# Altium schematic sheet FFCAD_GEN2.SchDoc(FFCAD_GEN2)
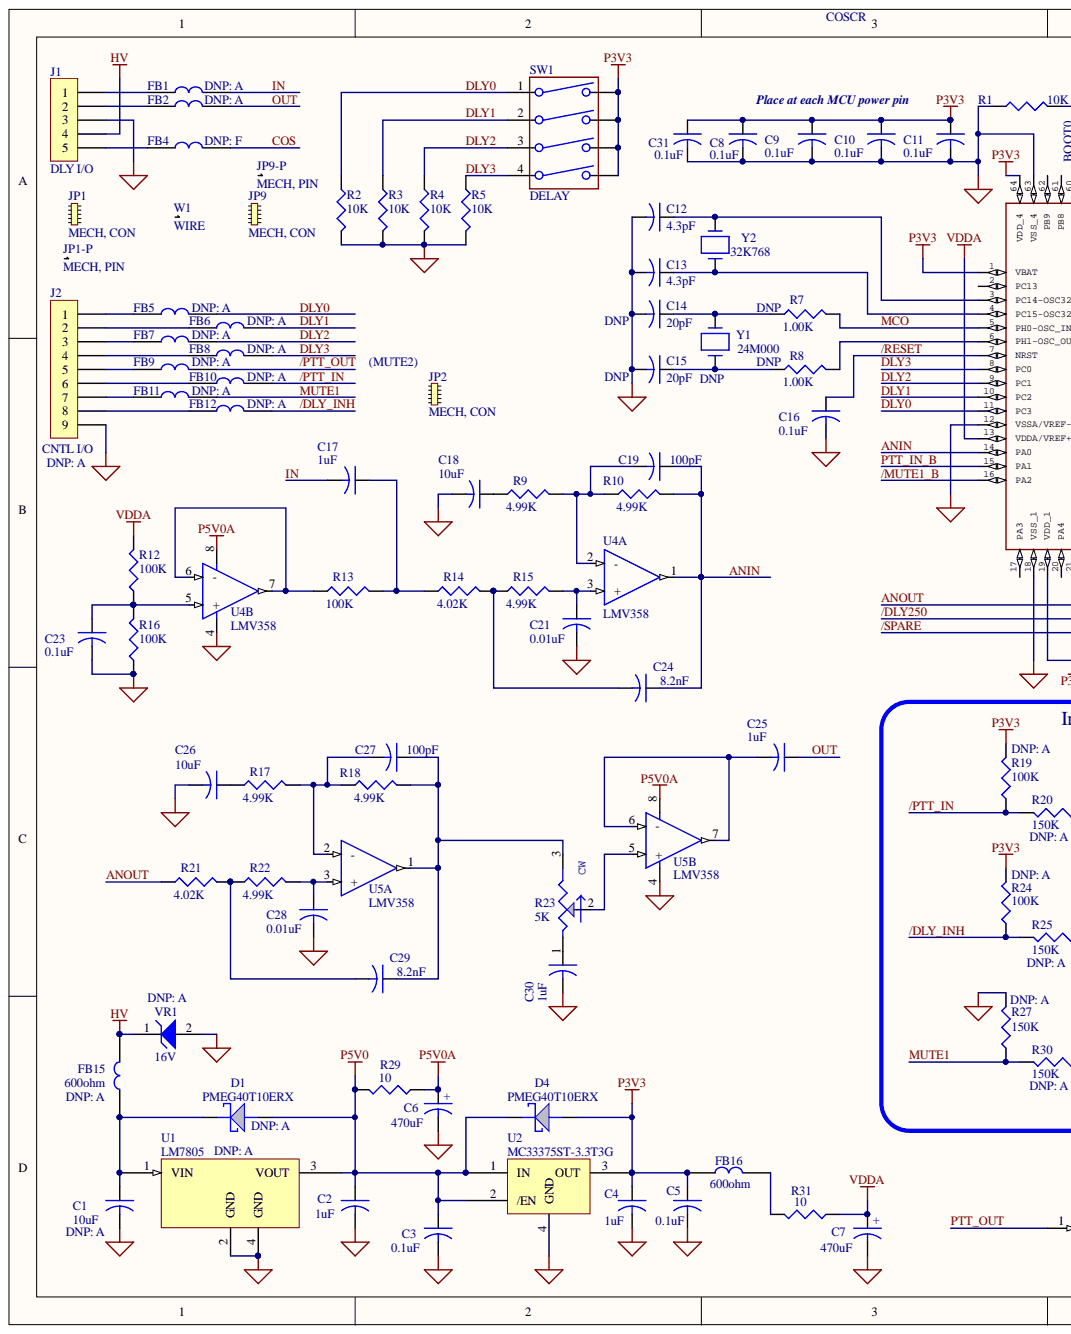
[diagram p0: FFCAD_GEN2.SchDoc, left]
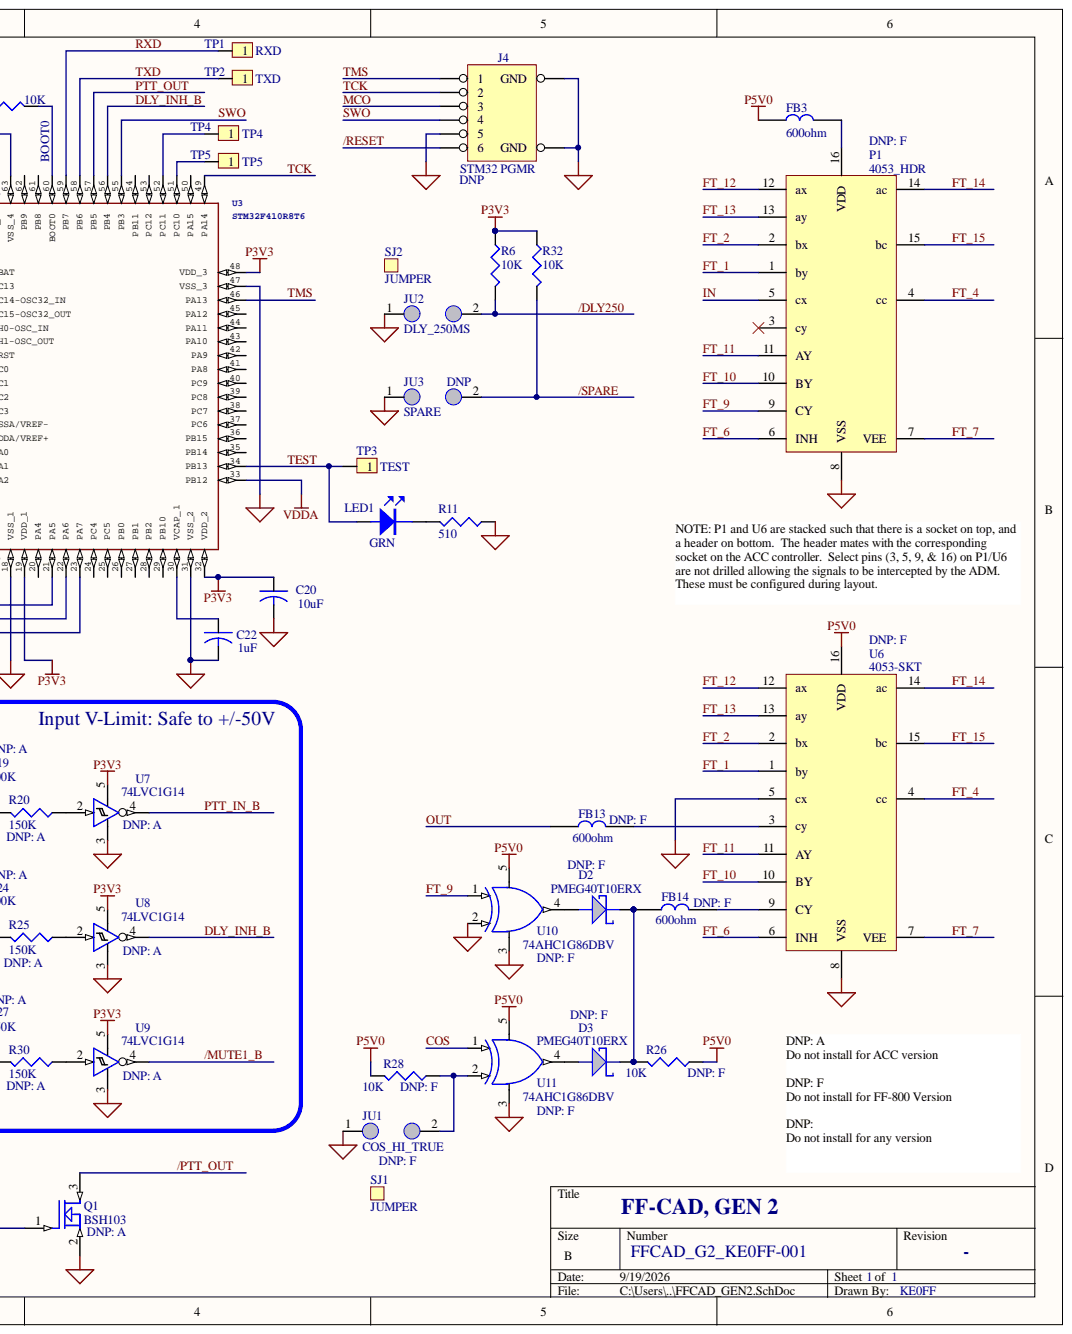
[diagram p1: FFCAD_GEN2.SchDoc, right]

## Parts
C1  10uF  DNP: DNP: A  [1206]
C2  1uF  [0805]
C3  0.1uF  [0402]
C4  1uF  [0805]
C5  0.1uF  [0402]
C6  470uF  [2917]
C7  470uF  [2917]
C8  0.1uF  [0402]
C9  0.1uF  [0402]
C10  0.1uF  [0402]
C11  0.1uF  [0402]
C12  4.3pF  [0402]
C13  4.3pF  [0402]
C14  20pF  [0402]
C15  20pF  [0402]
C16  0.1uF  [0402]
C17  1uF  [0805]
C18  10uF  [1206]
C19  100pF  [0402]
C20  10uF  [1206]
C21  0.01uF  [0805]
C22  1uF  [0805]
C23  0.1uF  [0402]
C24  8.2nF  [0805]
C25  1uF  [0805]
C26  10uF  [1206]
C27  100pF  [0402]
C28  0.01uF  [0805]
C29  8.2nF  [0805]
C30  1uF  [0805]
C31  0.1uF  [0402]
D1  PMEG40T10ERX  DNP: DNP: A  [SOD123]
D2  PMEG40T10ERX  DNP: DNP: F  [SOD123]
D3  PMEG40T10ERX  DNP: DNP: F  [SOD123]
D4  PMEG40T10ERX  [SOD123]
FB1  DNP: DNP: A  [0603]  (hidden comment: 600ohm)
FB2  DNP: DNP: A  [0603]  (hidden comment: 600ohm)
FB3  600ohm  [0603]
FB4  DNP: DNP: F  [0603]  (hidden comment: 600ohm)
FB5  DNP: DNP: A  [0603]  (hidden comment: 600ohm)
FB6  DNP: DNP: A  [0603]  (hidden comment: 600ohm)
FB7  DNP: DNP: A  [0603]  (hidden comment: 600ohm)
FB8  DNP: DNP: A  [0603]  (hidden comment: 600ohm)
FB9  DNP: DNP: A  [0603]  (hidden comment: 600ohm)
FB10  DNP: DNP: A  [0603]  (hidden comment: 600ohm)
FB11  DNP: DNP: A  [0603]  (hidden comment: 600ohm)
FB12  DNP: DNP: A  [0603]  (hidden comment: 600ohm)
FB13  600ohm  DNP: DNP: F  [0603]
FB14  600ohm  DNP: DNP: F  [0603]
FB15  600ohm  DNP: DNP: A  [0603]
FB16  600ohm  [0603]
J1  DLY I/O  [MOLEX-05]
J2  CNTL I/O  DNP: DNP: A  [MOLEX-09]
J4  STM32 PGMR  [CON_1.25MM_6P]
JP1  MECH, CON
JP1-P  MECH, PIN  (mechanical)
JP2  MECH, CON
JP9  MECH, CON
JP9-P  MECH, PIN  (mechanical)
JU1  COS_HI_TRUE  [BERG_1X2]
JU2  DLY_250MS  [BERG_1X2]
JU3  SPARE  [BERG_1X2]
LED1  GRN  [0603-LED]
P1  4053_HDR  DNP: DNP: F  [DIP16]
Q1  BSH103  [SOT23-A]
R1  10K  [0402]
R2  10K  [0402]
R3  10K  [0402]
R4  10K  [0402]
R5  10K  [0402]
R6  10K  [0402]
R7  1.00K  [0402]
R8  1.00K  [0402]
R9  4.99K  [0402]
R10  4.99K  [0402]
R11  510  [0402]
R12  100K  [0402]
R13  100K  [0402]
R14  4.02K  [0402]
R15  4.99K  [0402]
R16  100K  [0402]
R17  4.99K  [0402]
R18  4.99K  [0402]
R19  100K  DNP: DNP: A  [0402]
R20  150K  DNP: DNP: A  [0402]
R21  4.02K  [0402]
R22  4.99K  [0402]
R23  5K  [POT_3266W]
R24  100K  DNP: DNP: A  [0402]
R25  150K  DNP: DNP: A  [0402]
R26  10K  DNP: DNP: F  [0402]
R27  150K  DNP: DNP: A  [0402]
R28  10K  DNP: DNP: F  [0402]
R29  10  [0402]
R30  150K  DNP: DNP: A  [0402]
R31  10  [0402]
R32  10K  [0402]
SJ1  JUMPER  (mechanical)
SJ2  JUMPER  (mechanical)
SW1  DELAY  [DIP8]
TP1  RXD  [TP-SMD-20x50-SQ]  (no BOM)
TP2  TXD  [TP-SMD-20x50-SQ]  (no BOM)
TP3  TEST  [TP-SMD-20x50-SQ]  (no BOM)
TP4  TP4  [TP-SMD-20x50-SQ]  (no BOM)
TP5  TP5  [TP-SMD-20x50-SQ]  (no BOM)
U1  LM7805  DNP: DNP: A  [SOT223]
U2  MC33375ST-3.3T3G  [SOT223]
U3  STM32F410R8T6  [QFP50P1200X1200X160-64N]
U4  LMV358  [TSSOP-8]  (parts A,B)
U5  LMV358  [TSSOP-8]  (parts A,B)
U6  4053-SKT  DNP: DNP: F  [DIP16]
U7  74LVC1G14  DNP: DNP: A  [SOT-23-5]
U8  74LVC1G14  DNP: DNP: A  [SOT-23-5]
U9  74LVC1G14  DNP: DNP: A  [SOT-23-5]
U10  74AHC1G86DBV  DNP: DNP: F  [SOT-23-5]
U11  74AHC1G86DBV  DNP: DNP: F  [SOT-23-5]
VR1  16V  DNP: DNP: A  [SMB_POL]
W1  WIRE  (mechanical)
Y1  24M000  [XTAL_SMD]
Y2  32K768  [XTAL_AB25TRB]

## Nets
3: Y2.3
GND: C1.2 C2.2 C3.2 C4.2 C5.2 C6.2 C7.2 C8.2 C9.2 C10.2 C11.2 C12.2 C13.2 C14.2 C15.2 C16.2 C18.2 C20.2 C21.2 C22.2 C23.2 C26.2 C28.2 C30.2 C31.2 J1.3 J2.9 J4.5 J4.7(GND) J4.8(GND) JU1.1 JU2.1 JU3.1 P1.8(VSS) Q1.2 R1.1 R2.2 R3.2 R4.2 R5.2 R11.1 R16.1 R27.2 U1.2(GND) U1.4(GND) U2.4(GND) U3.12(VSSA/VREF-) U3.18(VSS_1) U3.31(VSS_2) U3.47(VSS_3) U3.63(VSS_4) U4.4(+) U5.4(+) U6.5(cx) U6.8(VSS) U7.3 U8.3 U9.3 U10.2 U10.3 U11.3 VR1.2
HV: FB15.2 J1.4 VR1.1
P3V3: C4.1 C5.1 C8.1 C9.1 C10.1 C11.1 C20.1 C31.1 D4.2 FB16.1 R6.1 R19.2 R24.2 R32.1 SW1.5 SW1.6 SW1.7 SW1.8 U2.3(OUT) U3.1(VBAT) U3.19(VDD_1) U3.32(VDD_2) U3.48(VDD_3) U3.64(VDD_4) U7.5 U8.5 U9.5
P5V0: C2.1 C3.1 D1.2 D4.1 FB3.1 R26.1 R28.2 R29.2 U1.3(VOUT) U2.1(IN) U2.2(/EN) U6.16(VDD) U10.5 U11.5
P5V0A: C6.1 R29.1 U4.8(-) U5.8
VDDA: C7.1 R12.2 R31.1 U3.13(VDDA/VREF+) U3.33(PB12)
/DLY250: JU2.2 R6.2 U3.22(PA6)
/DLY_INH: FB12.2 R24.1 R25.2
/MUTE1_B: U3.16(PA2) U9.4
/PTT_IN: FB10.2 R19.1 R20.2
/PTT_OUT: FB9.2 Q1.3
/RESET: C16.1 J4.6 U3.7(NRST)
/SPARE: JU3.2 R32.2 U3.23(PA7)
ANIN: C19.1 C24.1 R10.2 U3.14(PA0) U4.1
ANOUT: R21.1 U3.21(PA5)
COS: FB4.2 U11.1
DLY0: FB5.2 R2.1 SW1.1 U3.11(PC3)
DLY1: FB6.2 R3.1 SW1.2 U3.10(PC2)
DLY2: FB7.2 R4.1 SW1.3 U3.9(PC1)
DLY3: FB8.2 R5.1 SW1.4 U3.8(PC0)
DLY_INH_B: U3.56(PB4) U8.4
FT_1: P1.1(by) U6.1(by)
FT_2: P1.2(bx) U6.2(bx)
FT_4: P1.4(cc) U6.4(cc)
FT_6: P1.6(INH) U6.6(INH)
FT_7: P1.7(VEE) U6.7(VEE)
FT_9: P1.9(CY) U10.1
FT_10: P1.10(BY) U6.10(BY)
FT_11: P1.11(AY) U6.11(AY)
FT_12: P1.12(ax) U6.12(ax)
FT_13: P1.13(ay) U6.13(ay)
FT_14: P1.14(ac) U6.14(ac)
FT_15: P1.15(bc) U6.15(bc)
IN: C17.2 FB1.2 P1.5(cx)
MCO: J4.3 R7.2 U3.5(PH0-OSC_IN)
MUTE1: FB11.2 R27.1 R30.2
OUT: C25.1 FB2.2 FB13.1
PTT_IN_B: U3.15(PA1) U7.4
PTT_OUT: Q1.1 U3.57(PB5)
RXD: TP1.1 U3.59(PB7)
SWO: J4.4 U3.55(PB3)
TCK: J4.2 U3.49(PA14)
TEST: LED1.2 TP3.1 U3.34(PB13)
TMS: J4.1 U3.46(PA13)
TXD: TP2.1 U3.58(PB6)
NetC1_1: C1.1 D1.1 FB15.1 U1.1(VIN)
NetC12_1: C12.1 U3.3(PC14-OSC32_IN) Y2.1
NetC13_1: C13.1 U3.4(PC15-OSC32_OUT) Y2.2
NetC14_1: C14.1 R7.1 Y1.1
NetC15_1: C15.1 R8.1 Y1.2
NetC17_1: C17.1 R13.2 R14.1
NetC18_1: C18.1 R9.1
NetC19_2: C19.2 R9.2 R10.1 U4.2(-)
NetC21_1: C21.1 R15.2 U4.3(+)
NetC22_1: C22.1 U3.30(VCAP_1)
NetC23_1: C23.1 R12.1 R16.2 U4.5
NetC24_2: C24.2 R14.2 R15.1
NetC25_2: C25.2 U5.6(-) U5.7
NetC26_1: C26.1 R17.1
NetC27_1: C27.1 C29.1 R18.2 R23.3 U5.1
NetC27_2: C27.2 R17.2 R18.1 U5.2(-)
NetC28_1: C28.1 R22.2 U5.3(+)
NetC29_2: C29.2 R21.2 R22.1
NetC30_1: C30.1 R23.1
NetD2_1: D2.1 D3.1 FB14.1 R26.2
NetD2_2: D2.2 U10.4
NetD3_2: D3.2 U11.4
NetFB1_1: FB1.1 J1.1
NetFB2_1: FB2.1 J1.2
NetFB3_2: FB3.2 P1.16(VDD)
NetFB4_1: FB4.1 J1.5
NetFB5_1: FB5.1 J2.1
NetFB6_1: FB6.1 J2.2
NetFB7_1: FB7.1 J2.3
NetFB8_1: FB8.1 J2.4
NetFB9_1: FB9.1 J2.5
NetFB10_1: FB10.1 J2.6
NetFB11_1: FB11.1 J2.7
NetFB12_1: FB12.1 J2.8
NetFB13_2: FB13.2 U6.3(cy)
NetFB14_2: FB14.2 U6.9(CY)
NetFB16_2: FB16.2 R31.2
NetJU1_2: JU1.2 R28.1 U11.2
NetLED1_1: LED1.1 R11.2
NetR1_2: R1.2 U3.60(BOOT0)
NetR8_2: R8.2 U3.6(PH1-OSC_OUT)
NetR13_1: R13.1 U4.6 U4.7
NetR20_1: R20.1 U7.2
NetR23_2: R23.2 U5.5
NetR25_1: R25.1 U8.2
NetR30_1: R30.1 U9.2
NetTP4_1: TP4.1 U3.52(PC11)
NetTP5_1: TP5.1 U3.51(PC10)

Not connected: P1 3(cy); U3 2(PC13) 17(PA3) 20(PA4) 24(PC4) 25(PC5) 26(PB0) 27(PB1) 28(PB2) 29(PB10) 35(PB14) 36(PB15) 37(PC6) 38(PC7) 39(PC8) 40(PC9) 41(PA8) 42(PA9) 43(PA10) 44(PA11) 45(PA12) 50(PA15) 53(PC12) 54(PB11) 61(PB8) 62(PB9)

## Library data of the parts
C1 C18 C20 C26 = CAP 0805: CAP, MLCC, X7R, 25V, 0805, 10uF; MFR: Samsung; MPN: CL32B106KBJNNNF; VOLTAGE: 50V; Component Kind: Standard
  C18 C20 C26: DNP: -
C2 C4 C17 C22 C25 C30 = CAP 0805: CAP, MLCC, X7R, 50V, 0805, 1uF; MFR: Samsung; MPN: CL21B105KBFNNNE; Component Kind: Standard; DNP: -; VOLTAGE: 50V
C3 C5 C8-C11 C16 C23 C31 = CAP 0805: CAP, MLCC, X7R, 50V, 0402, 0.1uF; MFR: Murata; MPN: GRM155R71H104KE14J; Component Kind: Standard; DNP: -; VOLTAGE: 50V
C6 C7 = CAP 0805: CAP, TANT, 10V, 2917, 470uF; MFR: Vishay; MPN: TR3E477K6R3C0050; VOLTAGE: 6.3V; Component Kind: Standard; DNP: -
C12 C13 = CAP 0805: CAP, MLCC, C0G, 50V, 0402, 4.3pF; MFR: Yageo; MPN: CC0402BRNPO9BN4R3; Component Kind: Standard; DNP: -; VOLTAGE: 50V
C14 C15 = CAP 0805: CAP, MLCC, C0G, 50V, 0402, 20pF; MFR: Kemet; MPN: C0402C200J5GAC; Component Kind: Standard; DNP: DNP; VOLTAGE: 50V
C19 C27 = CAP 0805: CAP, MLCC, X7R, 50V, 0402, 100pF; MFR: Murata; MPN: GCM1555C1H101FA16D; VOLTAGE: 100V; Component Kind: Standard; DNP: -
C21 C28 = CAP 0805: CAP, MLCC, X7R, 50V, 0402, 0.01uF; MFR: Kemet; MPN: C0805C103F1GECAUTO; VOLTAGE: 100V; Component Kind: Standard; DNP: -
C24 C29 = CAP 0805: CAP, MLCC, C0G, 50V, 0805, 8.2nF; MFR: Kemet; MPN: C0805C822J2GACAUTO; Component Kind: Standard; DNP: -; VOLTAGE: 50V
D1-D4 = DIODE_SCHOTTKY_SOD123: DIODE, SHTKY; MFR: Nexperia; ALT-MPN: -; Component Kind: Standard; MPN: PMEG40T10ERX; NOTES: -; NOTES2: -; PRI-MPN: -; Library Name: Galaxy SCH-jem-1.08.SchLib
  D4: DNP: -
FB1-FB16 = FB: BD, FRT, SMD; MFR: TDK; MPN: MMZ1608S601ATD25; ALT-MPN: -; Component Kind: Standard; NOTES: -; NOTES2: -; PRI-MPN: -; Library Name: Galaxy SCH-jem-1.08.SchLib
  FB3 FB16: DNP: -
J1 = CON5: CON, Molex, 4-C, RIBS; ALT-MPN: 171857-0003; DNP: Use ALT MFR for ACC; ALT-MFR: Molex; Component Kind: Standard; MFR: Molex; MPN: 22-23-2041; NOTES: -; NOTES2: -; Library Name: Galaxy SCH-jem-1.08.SchLib
J2 = CON9: Connector, 9 pin; MFR: Molex; ALT-MPN: -; Component Kind: Standard; MPN: 22-23-2091; NOTES: -; NOTES2: -; PRI-MPN: -; Library Name: Galaxy SCH-jem-1.08.SchLib
J4 = HEADER-6-PIN-RT-ANG: 6-PIN JTAG HDR; ALT-MFR: Molex; ALT-MPN: ‎53261-0671 (R/A)‎; MPN: 53398-0671; Component Kind: Standard; DNP: DNP; MFR: Molex
JP1 = MECH_CON: CON, HSG, 3C, 0.1LS; MFR: Molex; DNP: DNP: F; Component Kind: Standard; Descr: CON, HSG, 3C; MPN: 22-01-3037; Library Name: Galaxy SCH-jem-1.08.SchLib
JP1-P = MECH_PIN: CON, CNTCT, CRMP; MFR: MOLEX; DNP: (QTY 14 for FF800, QTY 1 for ACC); Descr: CON, CNTCT, CRMP; MPN: 08-50-0114; Library Name: Galaxy SCH-jem-1.08.SchLib
JP2 = MECH_CON: CON, HSG, 9C, 0.1LS; MFR: Molex; DNP: DNP: A; Component Kind: Standard; Descr: CON, HSG, 9C; MPN: 22-01-3097; Library Name: Galaxy SCH-jem-1.08.SchLib
JP9 = MECH_CON: CON, HSG, 3C, 0.156LS; MFR: Molex; DNP: DNP: F; Component Kind: Standard; Descr: CON, HSG, 3C; MPN: 09-50-3031; Library Name: Galaxy SCH-jem-1.08.SchLib
JP9-P = MECH_PIN: CON, CNTCT, CRMP; MFR: Molex; DNP: DNP: F; Descr: CON, CNTCT, CRMP; MPN: 08-50-0106; Library Name: Galaxy SCH-jem-1.08.SchLib
JU1 = JUMPER_2POS: CON, JMPR, 2-PIN; MFR: HOUSE_STK; MPN: BRG1X2; DNP: DNP: F; ALT-MPN: -; Component Kind: Standard; NOTES: -; NOTES2: -; PRI-MPN: -; Library Name: Galaxy SCH-jem-1.08.SchLib
JU2 JU3 = JUMPER_2POS: CON, JMPR, 2-PIN; MFR: HOUSE_STK; MPN: BRG1X2; ALT-MPN: -; Component Kind: Standard; NOTES: -; NOTES2: -; PRI-MPN: -; Library Name: Galaxy SCH-jem-1.08.SchLib
  JU2: DNP: -
  JU3: DNP: DNP
LED1 = LED: LED, SMD, 0603; MFR: Dialight; MPN: 598-8070-107F; ALT-MPN: -; Component Kind: Standard; DNP: -; NOTES: -; NOTES2: -; PRI-MPN: -; Library Name: Galaxy SCH-jem-1.08.SchLib
P1 = 4053: HDR, DIP, 16C; MFR: Aries; MPN: 291574; NOTES: Jameco; ALT-MPN: -; Component Kind: Standard; NOTES2: -; PRI-MPN: -; Library Name: Galaxy SCH-jem-1.08.SchLib
Q1 = MOSFET N SOT23: TR, FET, N-CH; MFR: Nexperia; MPN: BSH103BKR; DNP: DNP: A; ALT-MPN: -; Component Kind: Standard; NOTES: -; NOTES2: -; PRI-MPN: -; Library Name: Galaxy SCH-jem-1.08.SchLib
R1-R6 R26 R28 R32 = R0805: RES, CHP, 0402, 1%, 10K; MFR: Yageo; MPN: RC0402FR-0710KL; ALT-MPN: -; Component Kind: Standard; NOTES: -; NOTES2: -; PRI-MPN: -; Library Name: Galaxy SCH-jem-1.08.SchLib
  R1-R6 R32: DNP: -
R7 R8 = R0805: RES, CHP, 0402, 1%, 1K; MFR: Yageo; MPN: RC0402FR-071KL; ALT-MPN: -; Component Kind: Standard; DNP: DNP; NOTES: -; NOTES2: -; PRI-MPN: -; Library Name: Galaxy SCH-jem-1.08.SchLib
R9 R10 R15 R17 R18 R22 = R0805: RES, CHP, 0402, 1%, 4.99K; MFR: Yageo; MPN: RC0402FR-074K99L; ALT-MPN: -; Component Kind: Standard; DNP: -; NOTES: -; NOTES2: -; PRI-MPN: -; Library Name: Galaxy SCH-jem-1.08.SchLib
R11 = R0805: RES, CHP, 0402, 1%, 510; MFR: Yageo; MPN: RC0402FR-07510RL; ALT-MPN: -; Component Kind: Standard; DNP: -; NOTES: -; NOTES2: -; PRI-MPN: -; Library Name: Galaxy SCH-jem-1.08.SchLib
R12 R13 R16 R19 R24 = R0805: RES, CHP, 0402, 1%, 100K; MFR: Yageo; MPN: RC0402FR-07100KL; ALT-MPN: -; Component Kind: Standard; NOTES: -; NOTES2: -; PRI-MPN: -; Library Name: Galaxy SCH-jem-1.08.SchLib
  R12 R13 R16: DNP: -
R14 R21 = R0805: RES, CHP, 0402, 1%, 4.02K; MFR: Yageo; MPN: RC0402FR-074K02L; ALT-MPN: -; Component Kind: Standard; DNP: -; NOTES: -; NOTES2: -; PRI-MPN: -; Library Name: Galaxy SCH-jem-1.08.SchLib
R20 R25 R27 R30 = R0805: RES, CHP, 0402, 1%, 150K; MFR: Yageo; MPN: RC0402FR-07150KL; ALT-MPN: -; Component Kind: Standard; NOTES: -; NOTES2: -; PRI-MPN: -; Library Name: Galaxy SCH-jem-1.08.SchLib
R23 = RESISTOR VAR: RES, VAR; MFR: Bourns; MPN: 3362P-1-502LF; ALT-MPN: -; Component Kind: Standard; DNP: -; NOTES: -; NOTES2: -; PRI-MPN: -; Library Name: Galaxy SCH-jem-1.08.SchLib
R29 R31 = R0805: RES, CHP, 0402, 1%, 10; MFR: Yageo; MPN: RC0402FR-0710RL; ALT-MPN: -; Component Kind: Standard; DNP: -; NOTES: -; NOTES2: -; PRI-MPN: -; Library Name: Galaxy SCH-jem-1.08.SchLib
SJ1 SJ2 = MECH_GEN: JMPR, SHRTNG, 0.1"; MFR: Harwin; MPN: M7581-46; DNP: -; Library Name: Galaxy SCH-jem-1.08.SchLib
SW1 = SW-DIP4: SW, DIP, PCB, 4POS; ALT-MFR: Jameco ValuePro; ALT-MPN: 38820; MFR: E-Switch; MPN: KAS1104ET; Component Kind: Standard; DNP: -; NOTES: -; NOTES2: -; Library Name: Galaxy SCH-jem-1.08.SchLib
TP1-TP5 = TP: MECH, TEST POINT; ALT-MPN: -; DNP: -; MFR: -; MPN: -; NOTES: -; NOTES2: -; PRI-MPN: -; Library Name: Galaxy SCH-jem-1.08.SchLib
U1 = LM7805: IC, REG, LIN, FXD, 5.0V; MFR: TI; MPN: UA78M05IDCYR; ALT-MPN: -; Component Kind: Standard; NOTES: -; NOTES2: -; PRI-MPN: -; Library Name: Galaxy SCH-jem-1.08.SchLib
U2 = MC33375ST-3.3T3G: IC, REG, LIN, FXD, 3.3V; MFR: On Semi; Component Kind: Standard; DNP: -; MPN: MC33375ST-3.3T3G; Library Name: MorseHID_revA.SCHLIB
U3 = STM32F410R8T6: IC, MCU, ARM-M4, STM32F410; MFR: STMicroelectronics; GateName_1: G$1; Component Kind: Standard; DeviceSetName: STM32F410R8T6; DNP: -; Height: 1.6mm; LibraryName: STM32F410R8T6; Mouser_Part_Number: 511-STM32F410R8T6; Mouser_Price-Stock: https://www.mouser.co.uk/ProductDetail/STMicroelectronics/STM32F410R8T6?qs=dTJS0cRn7ohlUnpO1y5gYA%3D%3D; MPN: STM32F410R8T6; SymbolName_1: STM32F410R8T6; Library Name: Galaxy SCH-jem-1.08.SchLib
U4 U5 = TL082: IC, OPAMP, JFET, DUAL; MFR: TI; MPN: LMV358IPWR; ALT-MPN: -; Component Kind: Standard; DNP: -; NOTES: -; NOTES2: -; PRI-MPN: -; Library Name: Galaxy SCH-jem-1.08.SchLib
U6 = 4053: SKT, DIP, 16C; ALT-MFR: Jameco ValuePro; ALT-MPN: 6100-16W-R; MFR: Mill-Max; MPN: 110-44-316-41-001000; Component Kind: Standard; NOTES: -; NOTES2: -; Library Name: Galaxy SCH-jem-1.08.SchLib
U7-U9 = 74ALVC1G14: IC, ALVC, SCHMT, NOT, SNGL; MFR: TI; MPN: SN74LVC1G14DBVT; ALT-MPN: -; Component Kind: Standard; NOTES: -; NOTES2: -; PRI-MPN: -; Library Name: Galaxy SCH-jem-1.08.SchLib
U10 U11 = 74AHC1G86DBV: IC,GATE,2IN,XOR,SINGLE; MFR: TI; ALT-MPN: -; Component Kind: Standard; MPN: 74AHC1G86DBV; NOTES: -; NOTES2: -; PRI-MPN: -; Library Name: Galaxy SCH-jem-1.08.SchLib
VR1 = TRANZORB UNI: DIO, TVS, UNIDIR, 16V; MFR: Bourns; MPN: SMBJ16A-H; ALT-MPN: -; Component Kind: Standard; NOTES: -; NOTES2: -; PRI-MPN: -; Library Name: Galaxy SCH-jem-1.08.SchLib
W1 = MECH_PIN: WIRE, HKP, 22AWG; MFR: ANY; MPN: AWG20; Descr: CON, WIRE, 6"; DNP: DNP: F; Library Name: Galaxy SCH-jem-1.08.SchLib
Y1 = CRYSTAL-2PIN: CRYSTAL 2 PIN; MFR: Abracon; MPN: ABM3-24.000MHZ-B2-T; Component Kind: Standard; DNP: DNP
Y2 = CRYSTAL-3PIN: CRYSTAL 3 PIN; MFR: Abracon; MPN: ABS25-32.768KHZ-6-T; Component Kind: Standard; DNP: -
